# Altium schematic sheet rf.SchDoc
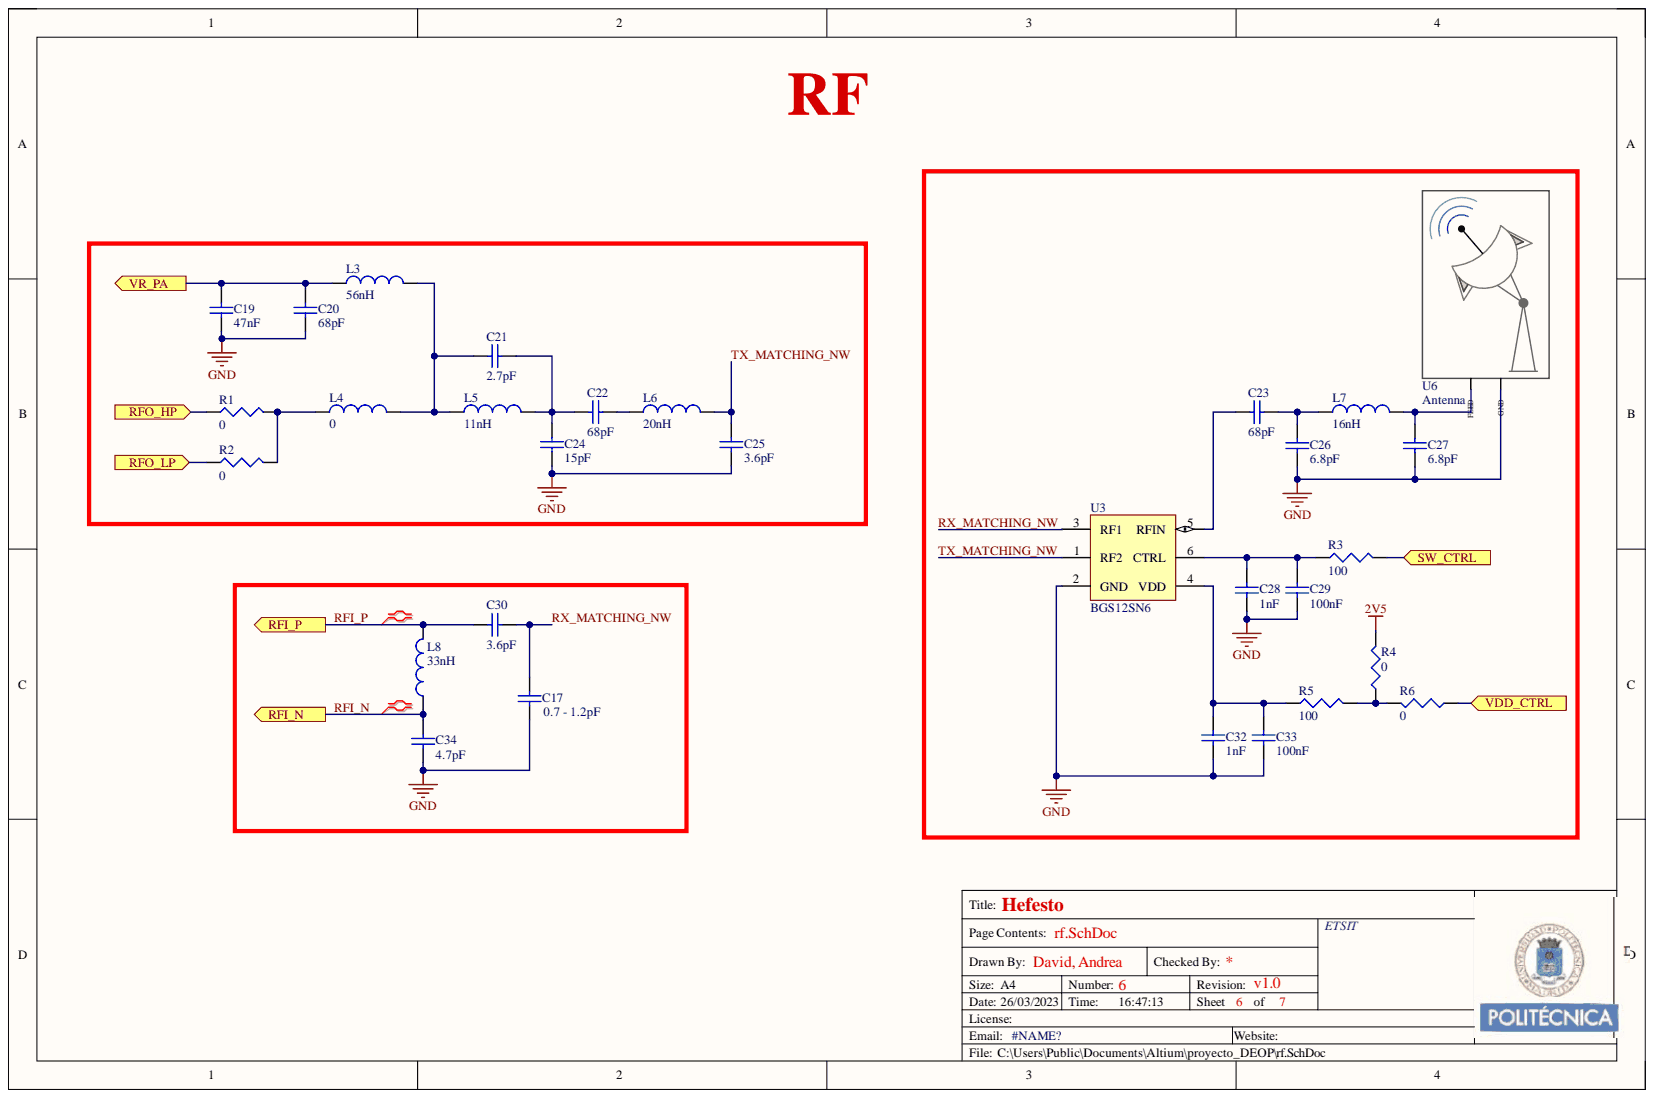
[diagram p0: rf.SchDoc]

## Parts
C17  value 0.7 - 1.2pF  [1608[0603]]  (hidden comment: Cap Semi)
C19  value 47nF  [1608[0603]]  (hidden comment: Cap Semi)
C20  value 68pF  [1608[0603]]  (hidden comment: Cap Semi)
C21  value 2.7pF  [1608[0603]]  (hidden comment: Cap Semi)
C22  value 68pF  [1608[0603]]  (hidden comment: Cap Semi)
C23  value 68pF  [1608[0603]]  (hidden comment: Cap Semi)
C24  value 15pF  [1608[0603]]  (hidden comment: Cap Semi)
C25  value 3.6pF  [1608[0603]]  (hidden comment: Cap Semi)
C26  value 6.8pF  [1608[0603]]  (hidden comment: Cap Semi)
C27  value 6.8pF  [1608[0603]]  (hidden comment: Cap Semi)
C28  value 1nF  [1608[0603]]  (hidden comment: Cap Semi)
C29  value 100nF  [1608[0603]]  (hidden comment: Cap Semi)
C30  value 3.6pF  [1608[0603]]  (hidden comment: Cap Semi)
C32  value 1nF  [1608[0603]]  (hidden comment: Cap Semi)
C33  value 100nF  [1608[0603]]  (hidden comment: Cap Semi)
C34  value 4.7pF  [1608[0603]]  (hidden comment: Cap Semi)
L3  value 56nH  [0603]  (hidden comment: Inductor)
L4  value 0  [0603]  (hidden comment: Inductor)
L5  value 11nH  [0603]  (hidden comment: Inductor)
L6  value 20nH  [0603]  (hidden comment: Inductor)
L7  value 16nH  [0603]  (hidden comment: Inductor)
L8  value 33nH  [0603]  (hidden comment: Inductor)
R1  value 0  [J1-0603]  (hidden comment: Res3)
R2  value 0  [J1-0603]  (hidden comment: Res3)
R3  value 100  [J1-0603]  (hidden comment: Res3)
R4  value 0  [J1-0603]  (hidden comment: Res3)
R5  value 100  [J1-0603]  (hidden comment: Res3)
R6  value 0  [J1-0603]  (hidden comment: Res3)
U3  BGS12SN6  [INF-TSNP-6-2_V]
U6  Antenna  [Antenna_SWRA228]

## Ports
RFI_N, RFI_P, RFO_HP, RFO_LP, SW_CTRL, VDD_CTRL, VR_PA

## Nets
2V5: R4.2
GND: C17.1 C19.1 C20.1 C24.1 C25.1 C26.2 C27.2 C28.2 C29.2 C32.2 C33.2 C34.1 U3.2(GND)
RFI_N: C34.2 L8.1 ; port RFI_N
RFI_P: C30.2 L8.2 ; port RFI_P
RFO_HP: R1.1 ; port RFO_HP
RFO_LP: R2.1 ; port RFO_LP
RX_MATCHING_NW: C17.2 C30.1 U3.3(RF1)
SW_CTRL: R3.2 ; port SW_CTRL
TX_MATCHING_NW: C25.2 L6.2 U3.1(RF2)
VDD_CTRL: R6.1 ; port VDD_CTRL
VR_PA: C19.2 C20.2 L3.1 ; port VR_PA
NetC21_1: C21.1 C22.2 C24.2 L5.2
NetC21_2: C21.2 L3.2 L4.2 L5.1
NetC22_1: C22.1 L6.1
NetC23_1: C23.1 C26.1 L7.1
NetC23_2: C23.2 U3.5(RFIN)
NetC27_1: C27.1 L7.2
NetC28_1: C28.1 C29.1 R3.1 U3.6(CTRL)
NetC32_1: C32.1 C33.1 R5.1 U3.4(VDD)
NetL4_1: L4.1 R1.2 R2.2
NetR4_1: R4.1 R5.2 R6.2
NetU6_0: U6.0
NetU6_1: U6.1

## Library data of the parts
C17 C19-C30 C32-C34 = Cap Semi: Capacitor (Semiconductor SIM Model); Component Kind: Standard; LatestRevisionDate: 29-May-2009; LatestRevisionNote: IPC-7351 Footprint Added.; Library Name: Miscellaneous Devices.IntLib; PackageDescription: Chip Capacitor; PackageReference: C1206; Published: 8-Jun-2000; Signal Integrity: Cap Semi; Simulation: CAP; Publisher: Altium Limited
L3-L8 = Inductor: Code_IEC: 1005; Code_JEITA: 0402; Component Kind: Standard; Description: Inductor; LatestRevisionDate: 29-May-2009; LatestRevisionNote: IPC-7351 Footprint Added.; Library Name: Miscellaneous Devices.IntLib; PackageDescription: Chip Inductor; PackageReference: 0402-A; Published: 8-Jun-2000; Signal Integrity: Inductor; Simulation: INDUCTOR; Publisher: Altium Limited
R1-R6 = Res3: Resistor; Component Kind: Standard; LatestRevisionDate: 29-May-2009; LatestRevisionNote: IPC-7351 Footprint Added.; Library Name: Miscellaneous Devices.IntLib; PackageDescription: Chip Resistor; PackageReference: J1-0603; Published: 8-Jun-2000; Signal Integrity: Res; Simulation: RESISTOR; Publisher: Altium Limited
U3 = CMP-1088-00016-1: Wideband RF SPDT Switch, 0.1 to 6 GHz, TSNP-6, Reel, Green; Manufacturer: Infineon; Green: TRUE; Insertion Loss @1GHz: 0.25 dB; Isolation @1GHz: 40 dB; Packing: Tape and Reel; Pmax: 30 dBm; Supply Voltage Range: 1.8 - 3.5; Component Kind: Standard; ComponentLink1Description: Manufacturer URL; ComponentLink1URL: http://www.infineon.com/; ComponentLink2Description: Datasheet; ComponentLink2URL: http://www.infineon.com/dgdl/BGS12SN6_2014-05-23.pdf?folderId=db3a30431f848401011fcbf2ab4c04c4&fileId=db3a30433f1b26e8013f2db58bc03856; ComponentLink3Description: Package Specification; ComponentLink3URL: http://www.infineon.com/dgdl/TSNP-6-2-Package_Overview.pdf?folderId=db3a304327b89750012805e1379a6124&fileId=db3a30433c6612b1013c6bcb02293ba1; DatasheetVersion: Rev. 1.2; Frequency ( f ) Range: 0.1 to 6 GHz; Halogen-free: TRUE; Library Name: Altium Content Vault; Mounting Technology: Surface Mount; PackageDescription: 6-Pin Thin Small Non Leaded Package, 1.1 x 0.7 mm Body, 0.4 mm Pitch; PackageReference: TSNP-6-2; PackageVersion: Jan-13; PartNumber: BGS12SN6; Switch Type: SPDT
U6 = Antenna: Component Kind: Standard; Library Name: DEOP2023.IntLib
